# Altium schematic sheet 06_POWER_3.SchDoc(06_POWER_3)
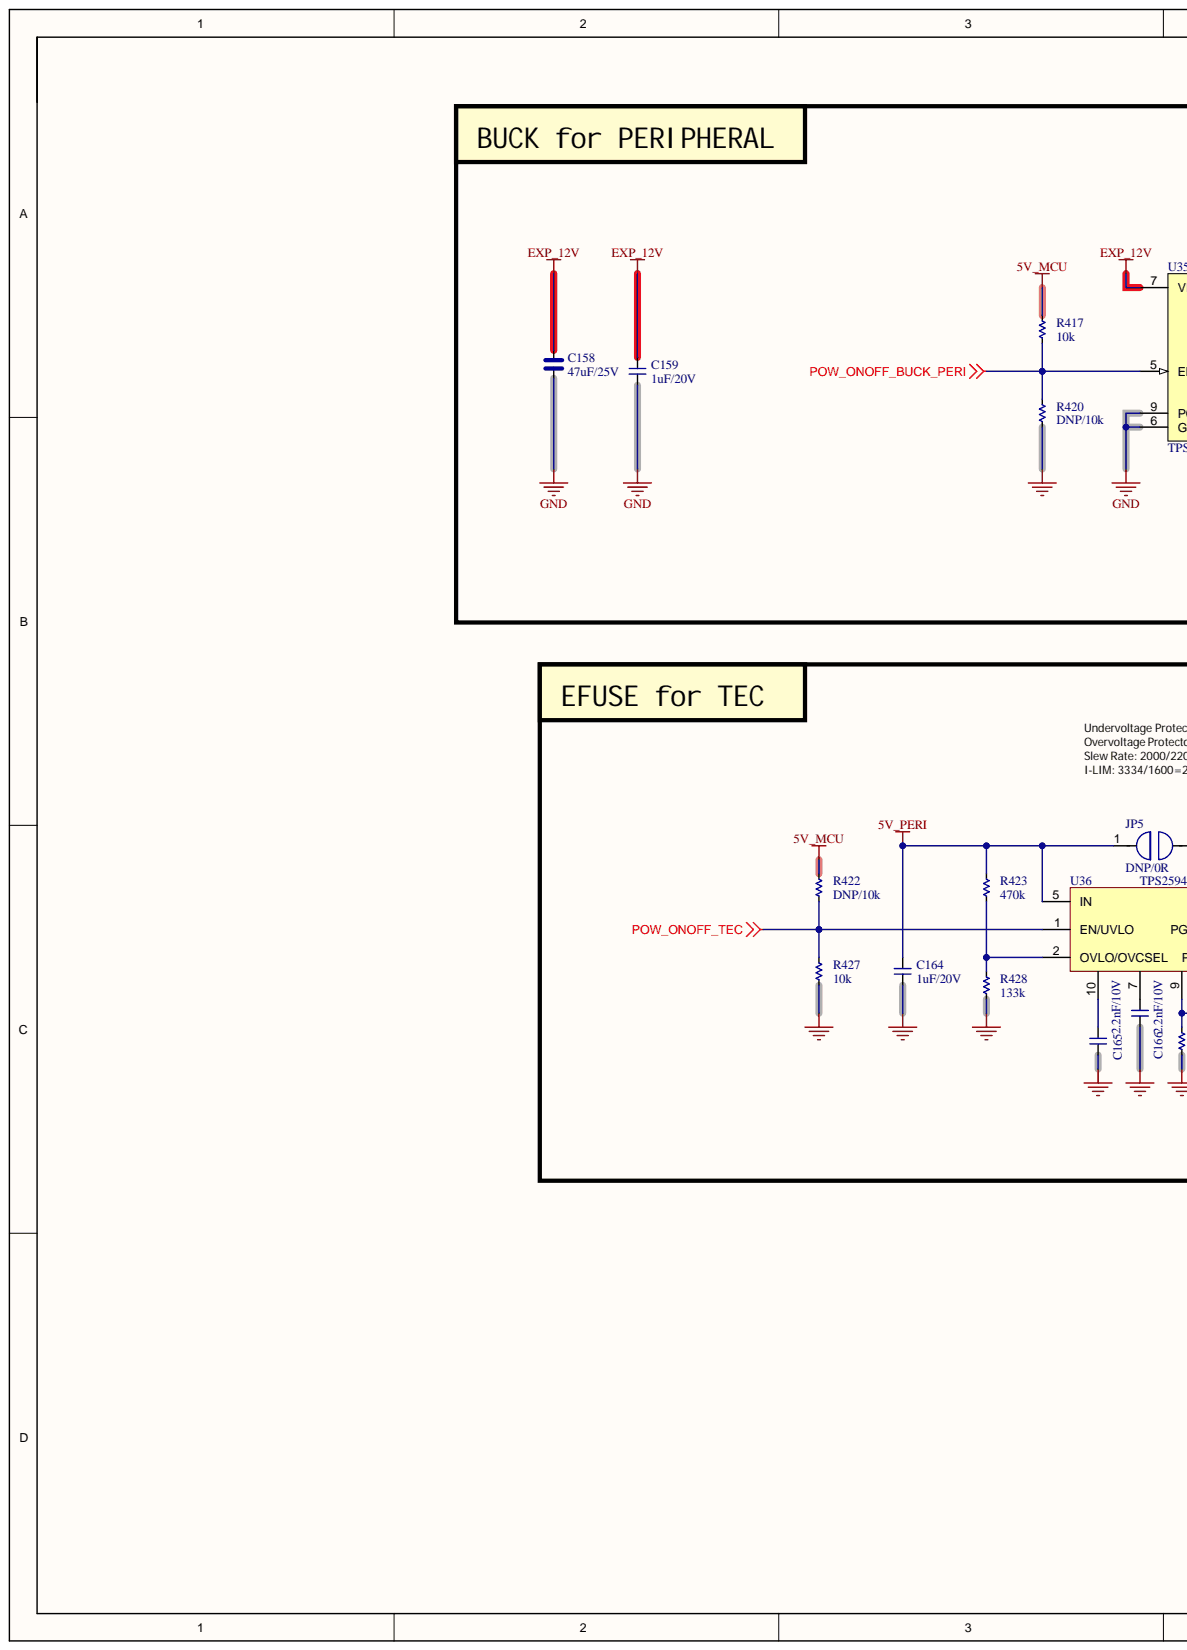
[diagram p0: 06_POWER_3.SchDoc, left]
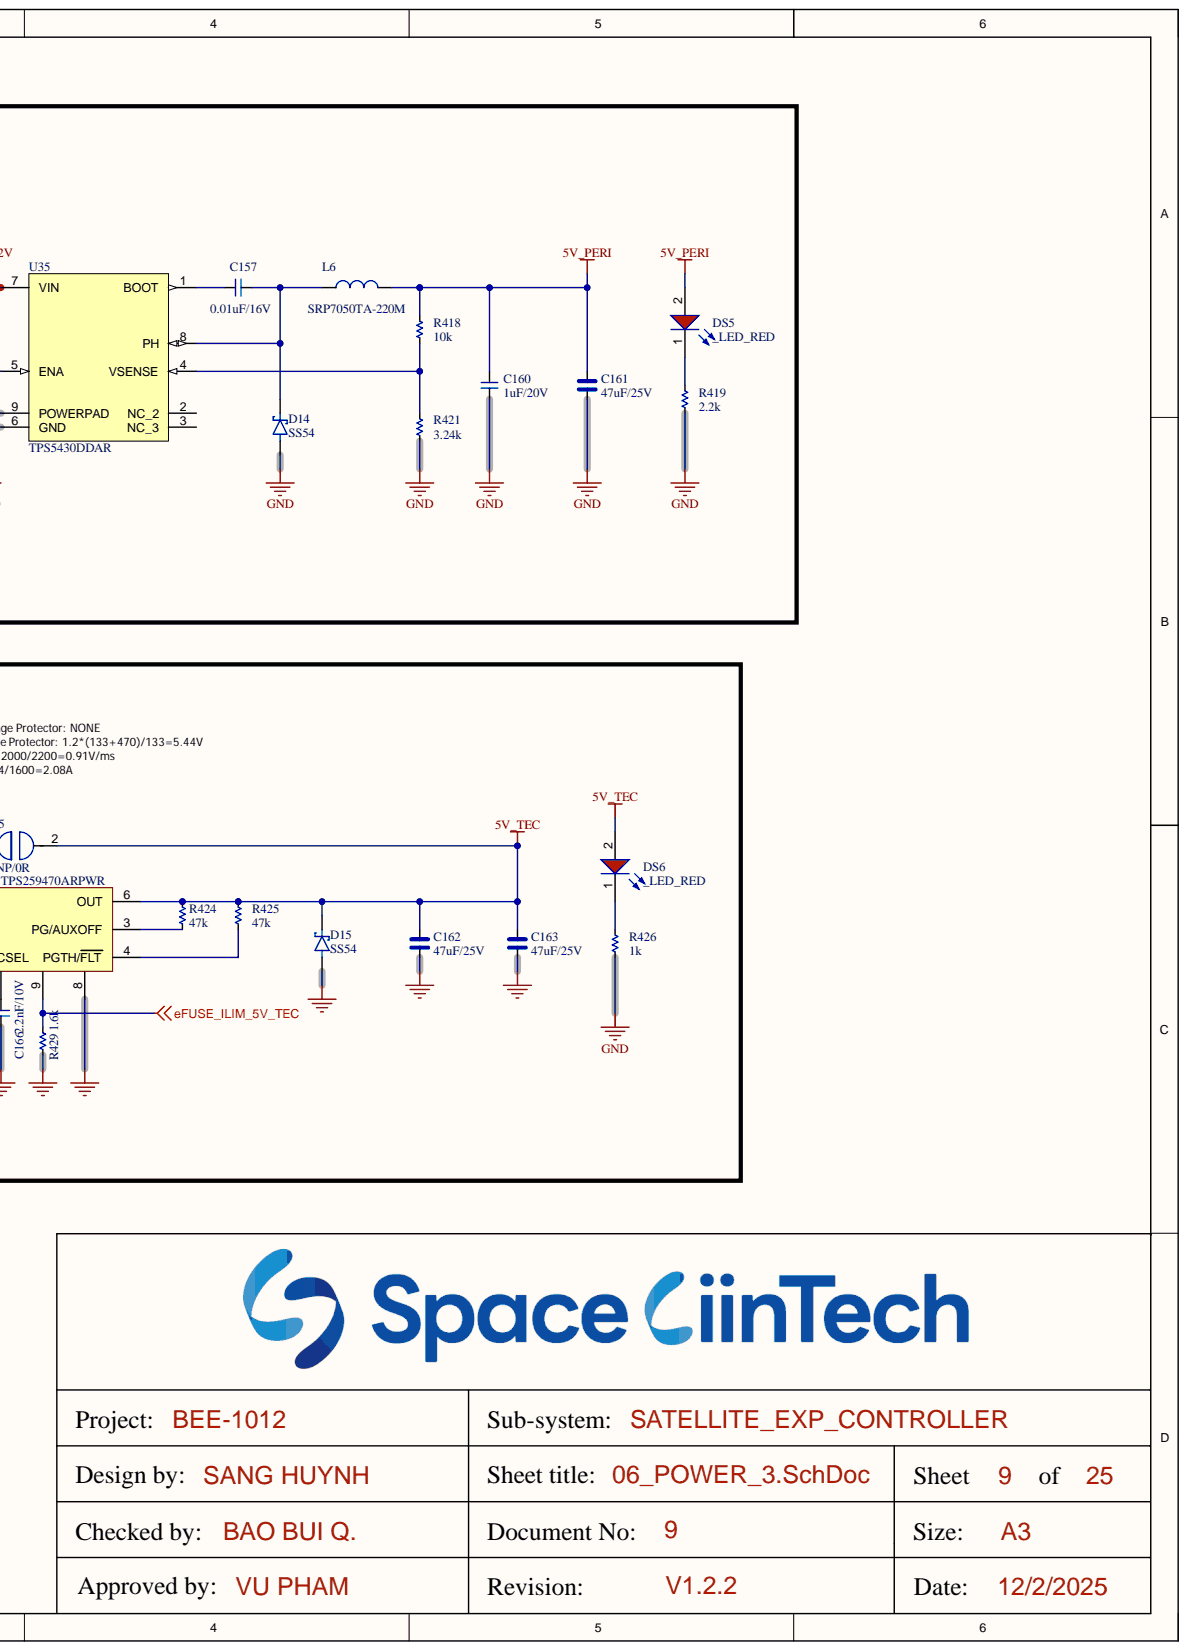
[diagram p1: 06_POWER_3.SchDoc, right]

## Parts
C157  0.01uF/16V  [CAP_C_1005X60]
C158  47uF/25V  [CAP_C_3225X80]
C159  1uF/20V  [CAP_C_1005X60]
C160  1uF/20V  [CAP_C_1005X60]
C161  47uF/25V  [CAP_C_3225X80]
C162  47uF/25V  [CAP_C_3225X80]
C163  47uF/25V  [CAP_C_3225X80]
C164  1uF/20V  [CAP_C_1005X60]
C165  2.2nF/10V  [CAP_C_1005X60]
C166  2.2nF/10V  [CAP_C_1005X60]
D14  SS54  [DO-214AC_SMA]
D15  SS54  [DO-214AC_SMA]
DS5  _LED_RED  [LED_0402]
DS6  _LED_RED  [LED_0402]
JP5  DNP/0R  [RES_C_1608X60]
L6  SRP7050TA-220M  [PSM6050]
R417  10k  [RES_C_1005X40]
R418  10k  [RES_C_1005X40]
R419  2.2k  [RES_C_1005X40]
R420  DNP/10k  [RES_C_1005X40]
R421  3.24k  [RES_C_1005X40]
R422  DNP/10k  [RES_C_1005X40]
R423  470k  [RES_C_1005X40]
R424  47k  [RES_C_1005X40]
R425  47k  [RES_C_1005X40]
R426  1k  [RES_C_1005X40]
R427  10k  [RES_C_1005X40]
R428  133k  [RES_C_1005X40]
R429  1.6k  [RES_C_1005X40]
U35  TPS5430DDAR  [TPS5430DDAR_V3]
U36  TPS259470ARPWR  [VQFN-HR-10]

## Nets
5V_MCU: R417.1 R422.1
5V_PERI: C160.2 C161.2 C164.2 DS5.2 JP5.1 L6.2 R418.2 R423.2 U36.5(IN)
5V_TEC: C162.2 C163.2 D15.1 DS6.2 JP5.2 R424.2 R425.2 U36.6(OUT)
eFUSE_ILIM_5V_TEC: R429.2 U36.9
EXP_12V: C158.2 C159.2 U35.7(VIN)
GND: C158.1 C159.1 C160.1 C161.1 C162.1 C163.1 C164.1 C165.1 C166.1 D14.2 D15.2 R419.1 R420.2 R421.1 R426.1 R427.2 R428.1 R429.1 U35.6(GND) U35.9(POWERPAD) U36.8
POW_ONOFF_BUCK_PERI: R417.2 R420.1 U35.5(ENA)
POW_ONOFF_TEC: R422.2 R427.1 U36.1(EN/UVLO)
NetC157_1: C157.1 D14.1 L6.1 U35.8(PH)
NetC157_2: C157.2 U35.1(BOOT)
NetC165_2: C165.2 U36.10
NetC166_2: C166.2 U36.7
NetDS5_1: DS5.1 R419.2
NetDS6_1: DS6.1 R426.2
NetR418_1: R418.1 R421.2 U35.4(VSENSE)
NetR423_1: R423.1 R428.2 U36.2(OVLO/OVCSEL)
NetR424_1: R424.1 U36.3(PG/AUXOFF)
NetR425_1: R425.1 U36.4(PGTH/FLT)

Not connected: U35 2(NC_2) 3(NC_3)

## Library data of the parts
C157 C159 C160 C164-C166 = _CAP_C_2: CAP CHIP; Component Kind: Standard; Library Name: LABLIB.SchLib
C158 C161-C163 = _CAP_C_High_2: CAP CHIP High Value; Component Kind: Standard; Library Name: LABLIB.SchLib
D14 D15 = DIODE SCHOTTKY: Component Kind: Standard; Description: DIODE SCHOTTKY; Library Name: LABLIB.SchLib
JP5 = JUMPER: Component Kind: Standard; Library Name: EXP_V1.SchLib
L6 = SRP7050TA-220M: FIXED IND 22UH 2.5A 170 MOHM SMD; Component Kind: Standard; Library Name: LABLIB.SchLib
R417-R429 = _RES_B_2: RES; Component Kind: Standard; Library Name: LABLIB.SchLib
U35 = TPS5430DDAR: IC REG BUCK ADJ 3A 8SOPWR; Component Kind: Standard; Library Name: EXP_HW_V3.SchLib
DS5 DS6 = _LED_RED: Component Kind: Standard; Library Name: LABLIB.SchLib
U36 = TPS259470ARPWR: Component Kind: Standard; Library Name: EXP_HW_V3.SchLib
